# Altium schematic sheet EMeter.SchDoc(EMeter)
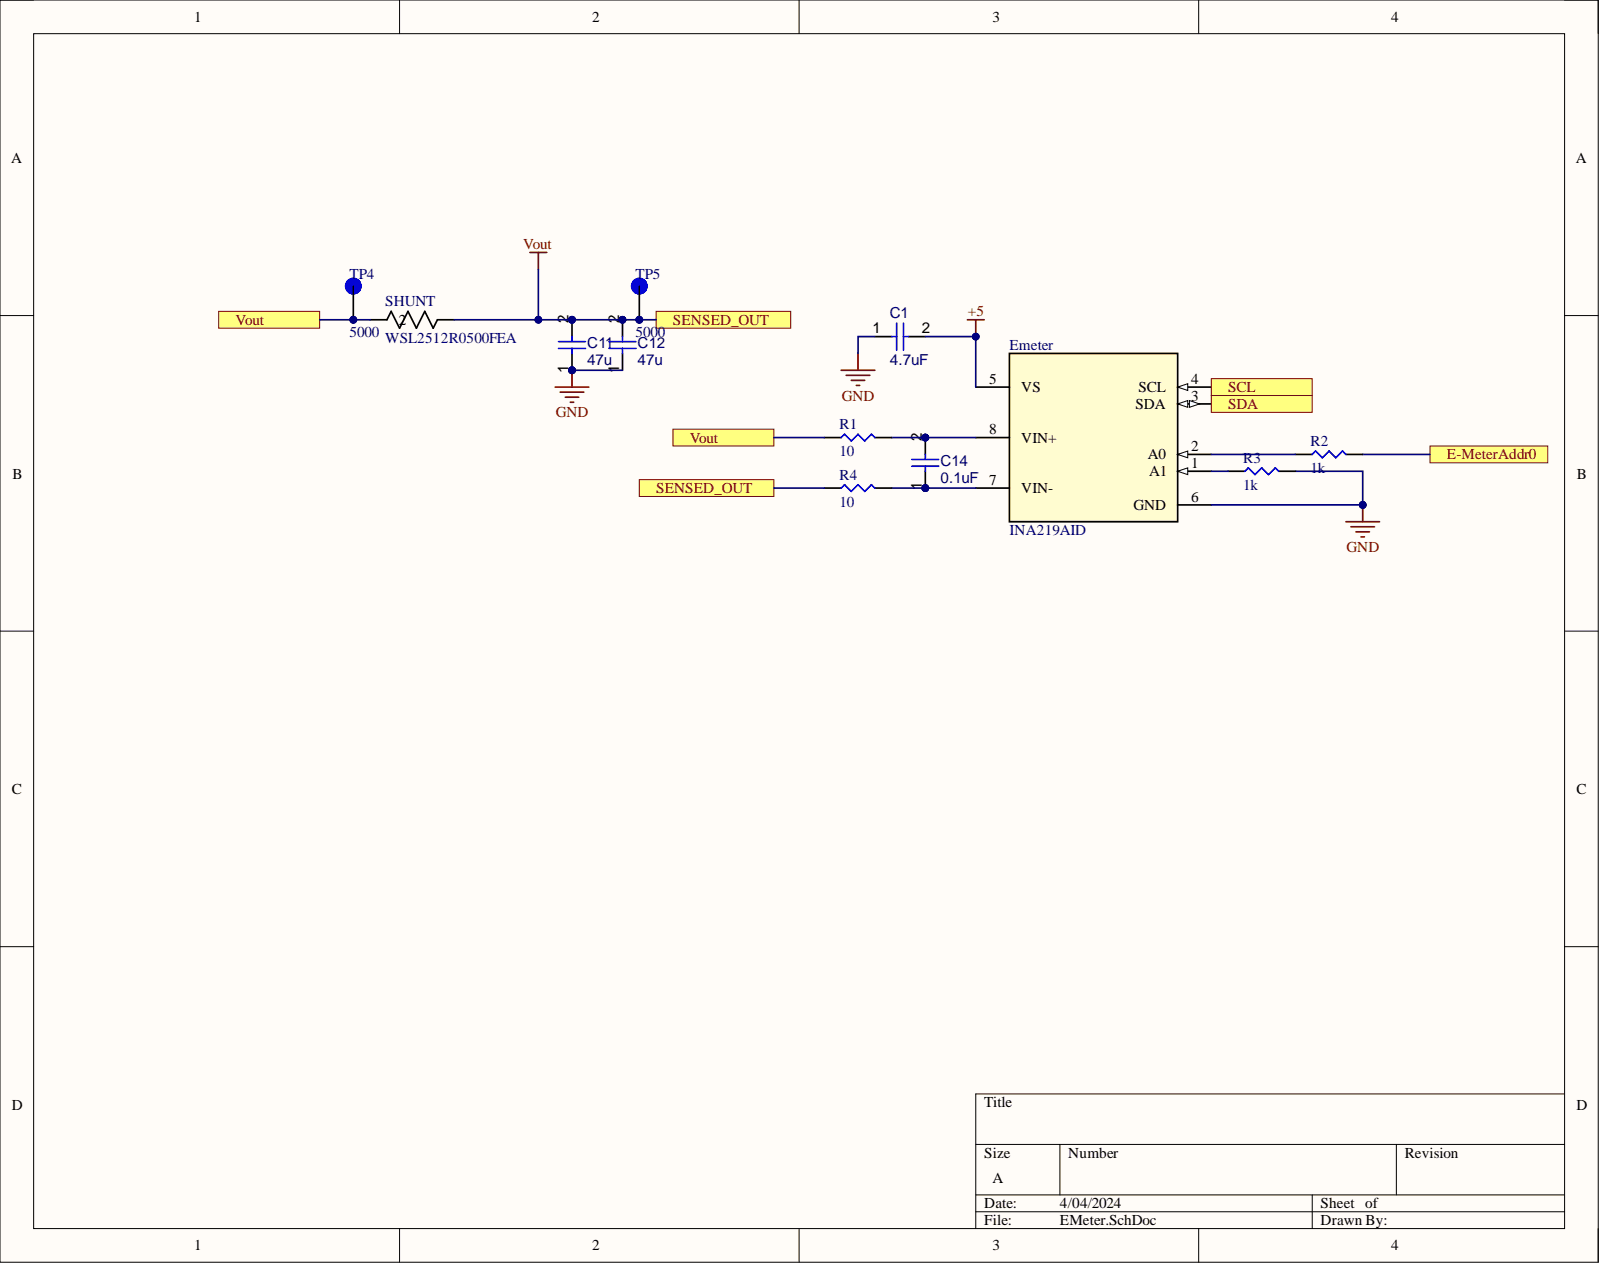
[diagram p0: EMeter.SchDoc]

## Parts
C1  4.7uF
C11  47u
C12  47u
C14  0.1uF
Emeter  INA219AID
R1  10
R2  1k
R3  1k
R4  10
SHUNT  WSL2512R0500FEA
TP4  5000
TP5  5000

## Ports
E-MeterAddr0, SCL, SDA, SENSED_OUT, Vout

## Nets
+5: C1.2 Emeter.5(VS)
GND: C1.1 C11.1 C12.1 Emeter.6(GND) R3.2
E-MeterAddr0: R2.2 ; port E-MeterAddr0
SCL: Emeter.4(SCL) ; port SCL
SDA: Emeter.3(SDA) ; port SDA
Vout: C11.2 C12.2 R4.1 SHUNT.1 TP5.TP ; port SENSED_OUT
NetC14_1: C14.1 Emeter.7(VIN-) R4.2
NetC14_2: C14.2 Emeter.8(VIN+) R1.2
NetEmeter_1: Emeter.1(A1) R3.1
NetEmeter_2: Emeter.2(A0) R2.1
NetR1_1: R1.1 SHUNT.2 TP4.TP ; port Vout

## Library data of the parts
C1 C11 C12 C14 = CMP-005-000638-1: Chip Capacitor, 1µF +/-40%, 50V, 0603, Thickness 1 mm; Available Preview Images: 2D; Component Kind: Standard; Design Item ID: CMP-001-00078-2; Source: Integrated_Library - 12.03.21 - 15.39.SchLib; Library Name: Illini Formula Electric
Emeter = CMP-114-000000-1: No Description Available; Alternate_PartNumber: INA219BID; Manufacturer: Texas Instruments; PartNumber: INA219BIDR; CompType: IC; Component Kind: Standard; Component Type: Standard; Design Item ID: INA219AID; Manufacturer_Part_Number: INA219AID; Mfr_Name: Texas Instruments; MountType: SMT; PackageReference: D0008A; Pin Count: 8; RefDes: RefDes; RoHS: Y; Source: INA219AID.SchLib; Type: DEV; Copyright: Copyright (C) 2024 Ultra Librarian. All rights reserved.; Library Name: Illini Formula Electric
R1-R4 = CMP-055-000088-1: Component Kind: Standard; Design Item ID: RES-0603; Library Name: Illini Formula Electric; Source: ife19_Elec_Library.SchLib
SHUNT = CMP-055-000185-1: No Description Available; Mfr_Name: Vishay; Component Kind: Standard; Component Type: Standard; Design Item ID: WSL2512R0500FEA; Manufacturer_Part_Number: WSL2512R0500FEA; Pin Count: 2; RefDes: RefDes; Source: WSL2512R0500FEA.SchLib; Type: DEV; Copyright: Copyright (C) 2024 Ultra Librarian. All rights reserved.; Library Name: Illini Formula Electric
TP4 TP5 = CMP-00067-1: Test Point Miniature THM H .300 Nylon 46 Insulated Red PhosBronze/Silver; MF: Keystone Electronics; Check_prices: https://www.snapeda.com/parts/5000/Keystone+Electronics/view-part/?ref=eda; Component Kind: Standard; Component Type: Standard; Design Item ID: 5000; MP: 5000; Package: Test Point Keystone Electronics; Pin Count: 1; SnapEDA_Link: https://www.snapeda.com/parts/5000/Keystone+Electronics/view-part/?ref=snap; Source: 5000.SchLib; Library Name: Illini Formula Electric
